# Altium schematic sheet 1_BUTTONS.SchDoc
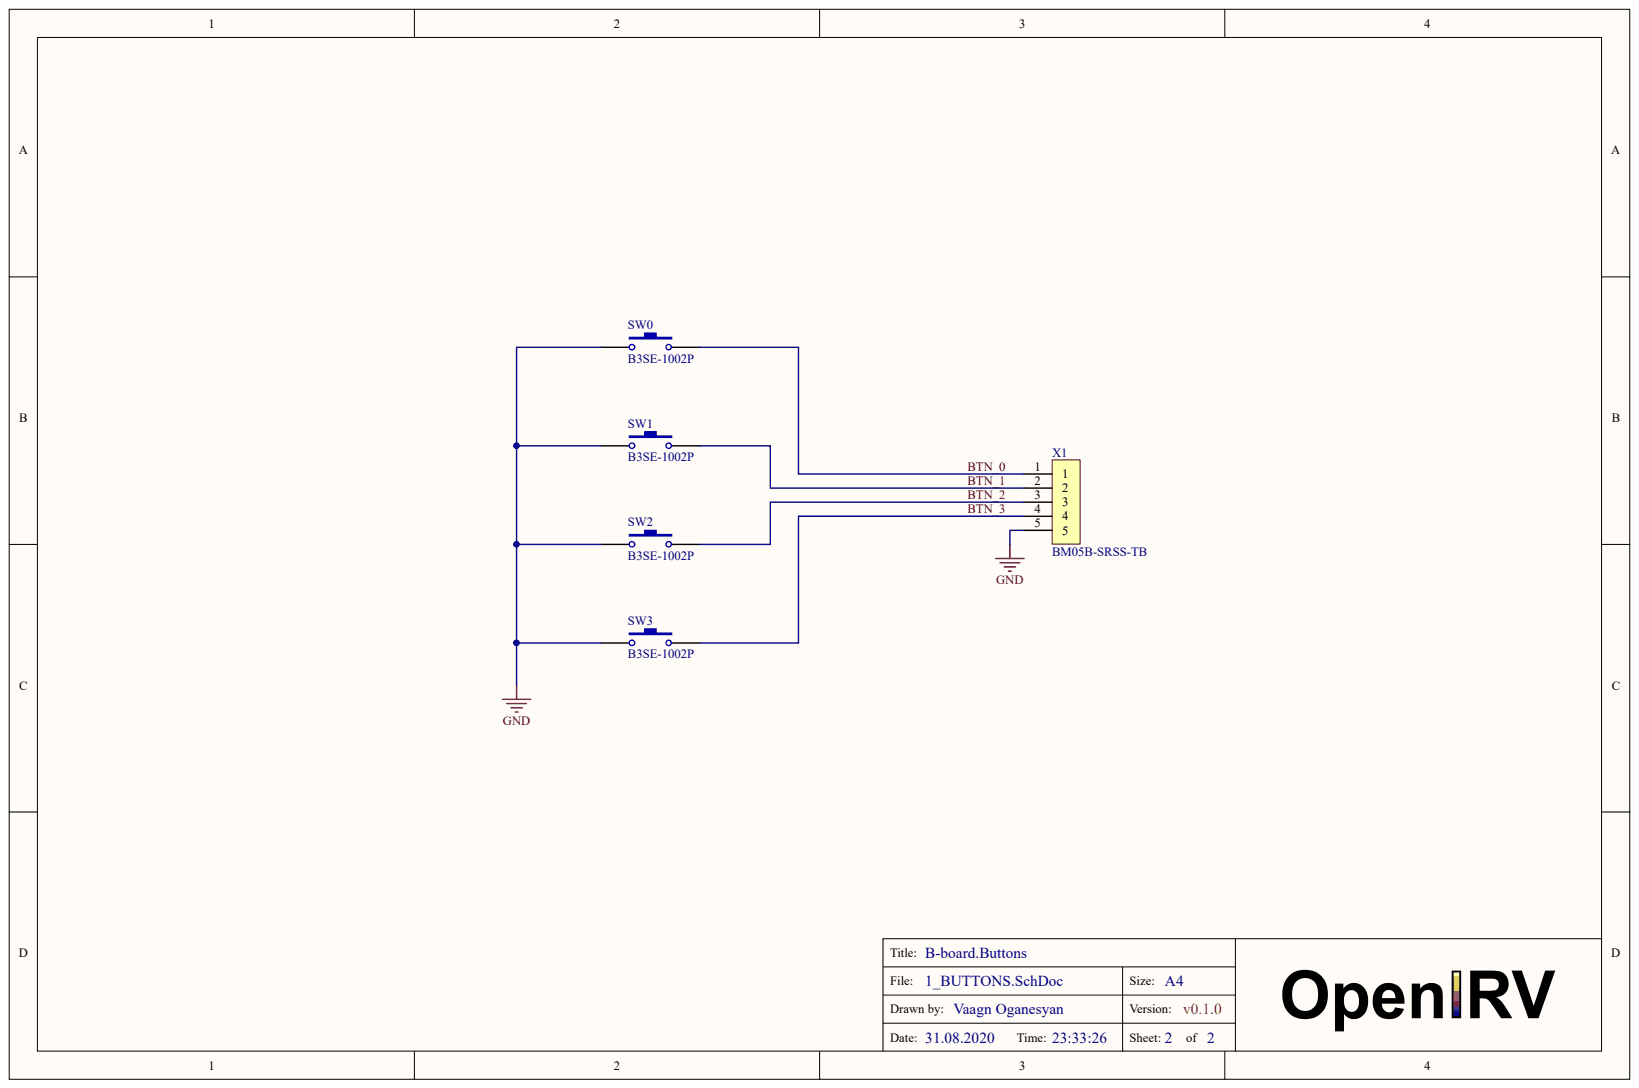
[diagram p0: 1_BUTTONS.SchDoc]

## Parts
SW0  B3SE-1002P  [B3SE-1002P]
SW1  B3SE-1002P  [B3SE-1002P]
SW2  B3SE-1002P  [B3SE-1002P]
SW3  B3SE-1002P  [B3SE-1002P]
X1  BM05B-SRSS-TB  [BM05B-SRSS-TB]

## Nets
GND: SW0.2 SW1.2 SW2.2 SW3.2 X1.5
BTN_0: SW0.1 X1.1
BTN_1: SW1.1 X1.2
BTN_2: SW2.1 X1.3
BTN_3: SW3.1 X1.4

## Library data of the parts
SW0-SW3 = Button: Tactile Switch; Component Kind: Standard; Library Name: general.IntLib; ManufacturerPartNumber: B3SE-1002P
X1 = BM05B-SRSS-TB: Component Kind: Standard; Library Name: general.IntLib
